# Altium schematic sheet Microcontrollers.SchDoc(Microcontrollers)
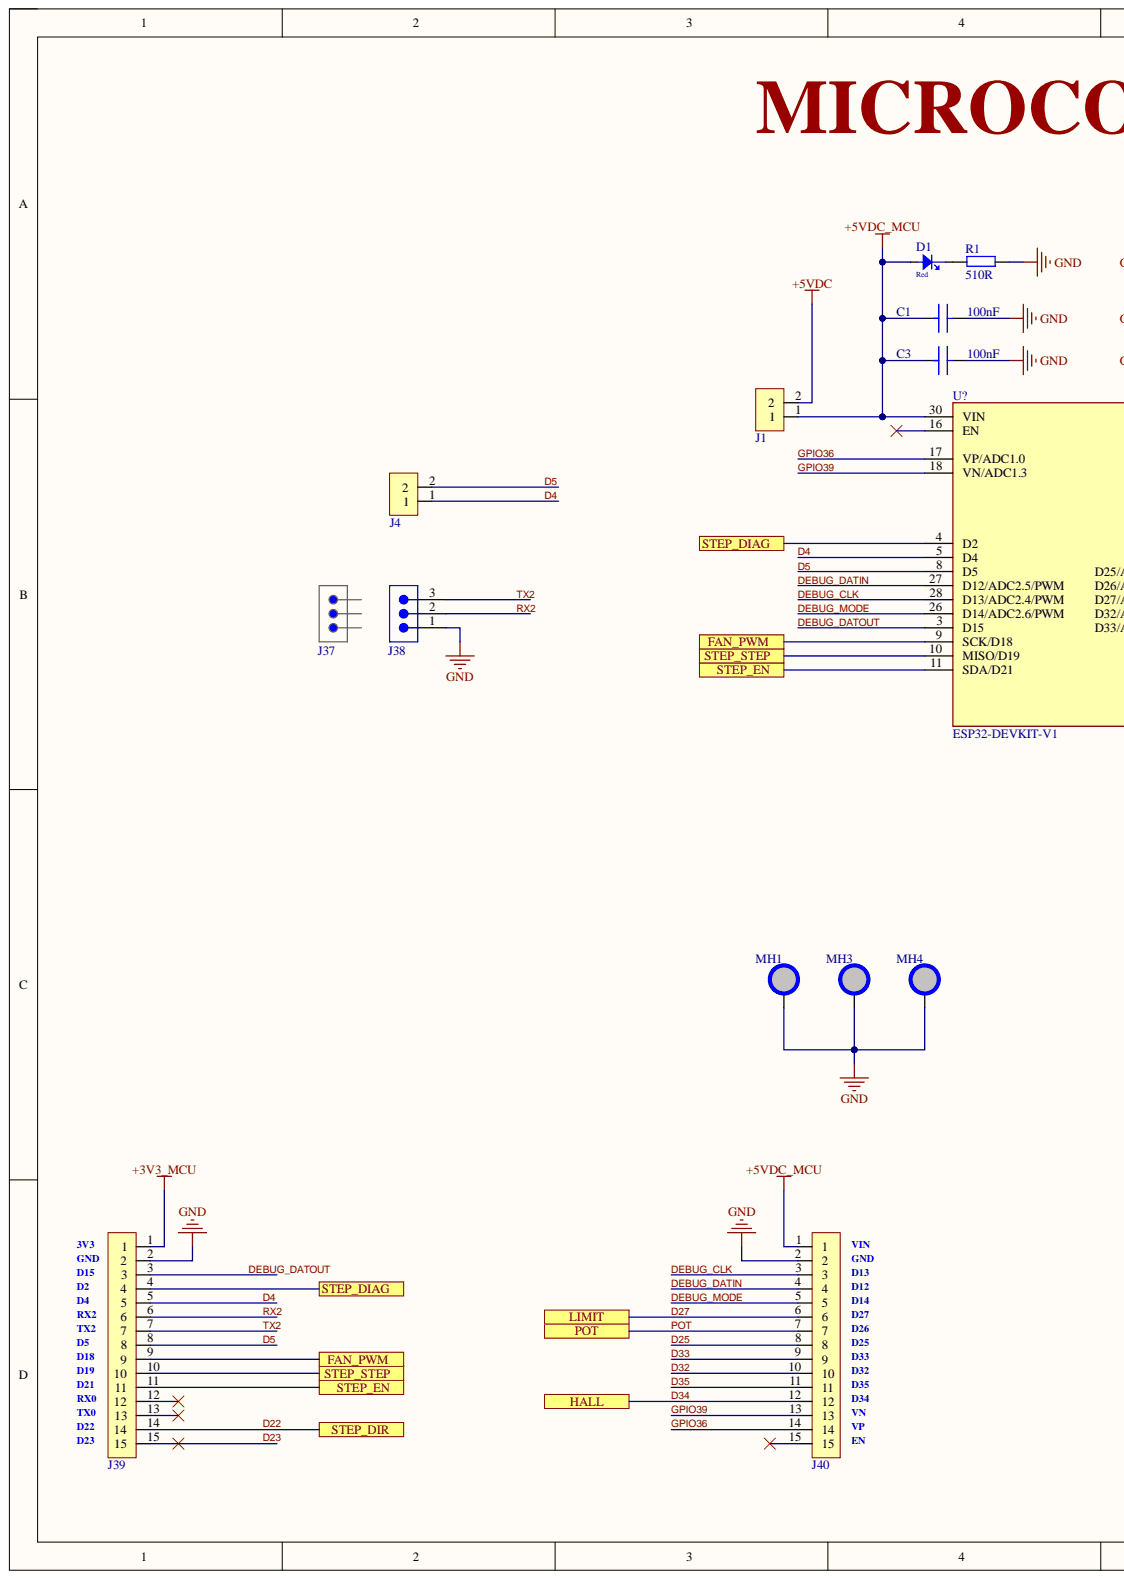
[diagram p0: Microcontrollers.SchDoc, left]
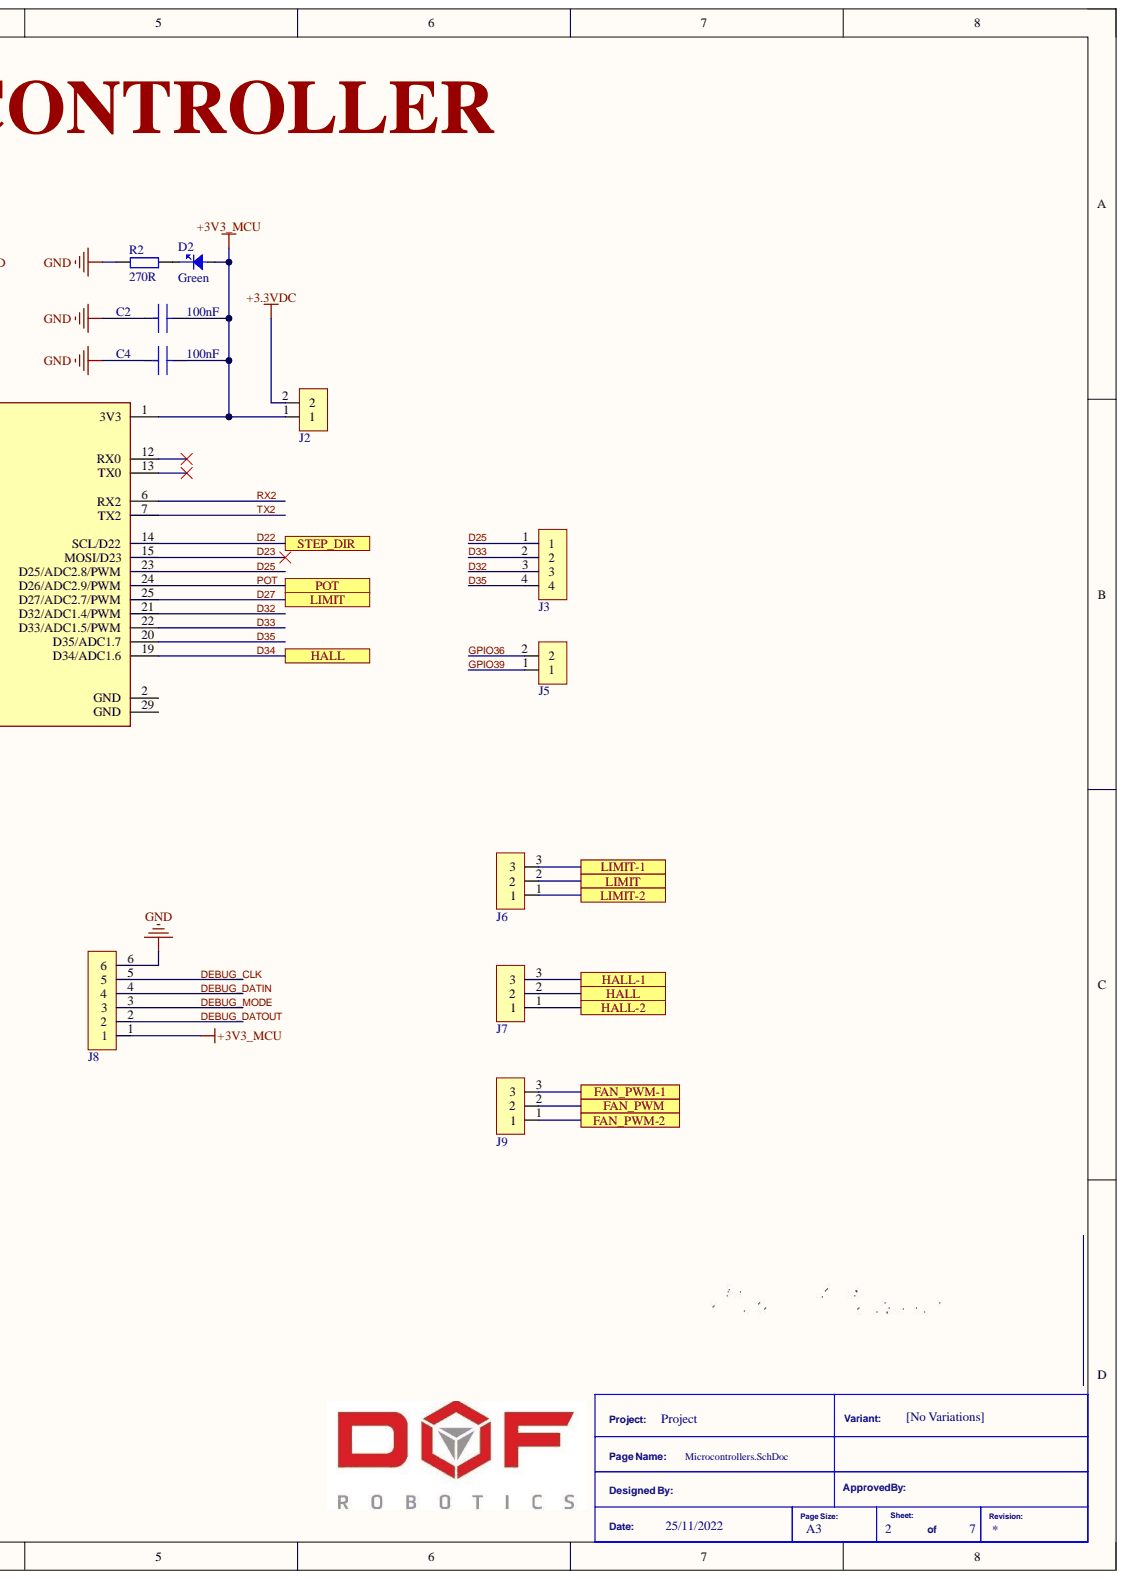
[diagram p1: Microcontrollers.SchDoc, right]

## Parts
C1  Capacitance: 100nF  [CAP CER 0805]  (hidden comment: CL21B104KACNNNC)
C2  Capacitance: 100nF  [CAP CER 0805]  (hidden comment: CL21B104KACNNNC)
C3  Capacitance: 100nF  [CAP CER 0805]  (hidden comment: CL21B104KACNNNC)
C4  Capacitance: 100nF  [CAP CER 0805]  (hidden comment: CL21B104KACNNNC)
D1  Color: Red  [LED RED 0603]  (hidden comment: 150060RS75000)
D2  Color: Green  [LED GREEN 0805]  (hidden comment: CMD17-21VGD/TR8)
J1  61300211121  [HDR_ML_1X2]  (value not drawn)
J2  61300211121  [HDR_ML_1X2]  (value not drawn)
J3  M20-9990345  [HDR_ML_1X4]  (value not drawn)
J4  61300211121  [HDR_ML_1X2]  (value not drawn)
J5  61300211121  [HDR_ML_1X2]  (value not drawn)
J6  61300311121  [HDR_ML_1X3]  (value not drawn)
J7  61300311121  [HDR_ML_1X3]  (value not drawn)
J8  61300611121  [HDR_ML_1x6]  (value not drawn)
J9  61300311121  [HDR_ML_1X3]  (value not drawn)
J37  691352710003  [CONN FML 1X3 WURTH 691352710003]  (value not drawn)
J38  691313710003  [CONN ML 1X3 WURTH 691313710003]  (value not drawn)
J39  M20-7821546  [HDR_FML_1X15]  (value not drawn)
J40  M20-7821546  [HDR_FML_1X15]  (value not drawn)
MH1  MH_5.5MMC_3.1MMD  [MH_5.5MMC_3.1MMD]  (value not drawn)  (no BOM)
MH3  MH_5.5MMC_3.1MMD  [MH_5.5MMC_3.1MMD]  (value not drawn)  (no BOM)
MH4  MH_5.5MMC_3.1MMD  [MH_5.5MMC_3.1MMD]  (value not drawn)  (no BOM)
R1  Resistance: 510R  [RES 0603]  (hidden comment: ESR03EZPJ511)
R2  Resistance: 270R  [RES 0805]  (hidden comment: CRGCQ0805F270R)

## Ports
FAN_PWM, FAN_PWM-1, FAN_PWM-2, HALL, HALL-1, HALL-2, LIMIT, LIMIT-1, LIMIT-2, POT, STEP_DIAG, STEP_DIR, STEP_EN, STEP_STEP

## Nets
+3.3VDC: J2.2
+3V3_MCU: C2.1 C4.1 D2.2 J2.1 J8.1 J39.1
+5VDC: J1.2
+5VDC_MCU: C1.2 C3.2 D1.2 J1.1 J40.1
GND: C1.1 C2.2 C3.1 C4.2 J8.6 J38.1 J39.2 J40.2 MH1.1 MH3.1 MH4.1 R1.2 R2.2
D4: J4.1 J39.5
D5: J4.2 J39.8
D22: J39.14 ; port STEP_DIR
D23: J39.15
D25: J3.1 J40.8
D27: J6.2 J40.6 ; port LIMIT
D32: J3.3 J40.10
D33: J3.2 J40.9
D34: J7.2 J40.12 ; port HALL
D35: J3.4 J40.11
DEBUG_CLK: J8.5 J40.3
DEBUG_DATIN: J8.4 J40.4
DEBUG_DATOUT: J8.2 J39.3
DEBUG_MODE: J8.3 J40.5
FAN_PWM: J9.2 J39.9 ; port FAN_PWM
FAN_PWM-1: J9.3 ; port FAN_PWM-1
FAN_PWM-2: J9.1 ; port FAN_PWM-2
GPIO36: J5.2 J40.14
GPIO39: J5.1 J40.13
HALL-1: J7.3 ; port HALL-1
HALL-2: J7.1 ; port HALL-2
LIMIT-1: J6.3 ; port LIMIT-1
LIMIT-2: J6.1 ; port LIMIT-2
POT: J40.7 ; port POT
RX2: J38.2 J39.6
STEP_DIAG: J39.4 ; port STEP_DIAG
STEP_EN: J39.11 ; port STEP_EN
STEP_STEP: J39.10 ; port STEP_STEP
TX2: J38.3 J39.7
NetD1_1: D1.1 R1.1
NetD2_1: D2.1 R2.1

Not connected: J39 12 13; J40 15

## Library data of the parts
C1-C4 = CAP CER 0.1UF 25V X7R 0805: Manufacturer: Samsung; Tolerance: 10%; Case Code (Imperial): 0805; Case Code (Metric): 2012; Case/Package: 0805; Category: Ceramic Capacitors; Component Kind: Standard; ComponentLink1Description: https://datasheet.ciiva.com/25801/cl21b104kacnnnc-25801824.pdf?src-supplier=Digi-Key; ComponentLink1URL: https://datasheet.ciiva.com/25801/cl21b104kacnnnc-25801824.pdf?src-supplier=Digi-Key; ComponentLink2Description: https://datasheet.ciiva.com/15216/getdatasheetpartid-355204-15216236.pdf?src-supplier=Verical; ComponentLink2URL: https://datasheet.ciiva.com/15216/getdatasheetpartid-355204-15216236.pdf?src-supplier=Verical; Construction: Flat; Contact Plating: Tin; Depth: 1.25mm; Description: CAP CER 0.1UF 25V X7R 0805; Dielectric: X7R; Height: 0.85mm; Length: 2mm; Manufacturer Part Number: CL21B104KACNNNC; Max Operating Temperature: 125°C; Min Operating Temperature: -55°C; Mount: Surface Mount; Package Quantity: 1; Packaging: Tape and Reel; Pricing 1: 1=0.10000, 10=0.04000, 50=0.02200, 100=0.01790, 500=0.01282, 1000=0.01007 (USD); Radiation Hardening: No; RoHS: Yes; RoHS Compliant: Yes; Series: CL; Stock 1: 2617; Supplier 1: Digi-Key; Supplier Part Number 1: 1276-1099-1-ND; Thickness: 0.037inch; Voltage: 25V; Voltage Rating: 25V; Width: 0.049inch; Library Name: LibEnes.SchLib
D1 = LED RED 0603: WURTH ELEKTRONIK - 150060RS75000 - LED, Red, 625 nm, 2 V, 30 mA, 250 mcd RoHS Compliant: Yes; Manufacturer: Wurth Electronics; Dominant Wavelength: 625nm; Forward Current: 30mA; Forward Voltage: 2V; Lens Style: Clear,Rectangular; Luminous Intensity: 250mcd; Test Current: 20mA; Viewing Angle: 140°; Case Code (Imperial): 0603; Case Code (Metric): 1608; Case/Package: 0603; Category: LEDs; Component Kind: Standard; ComponentLink1Description: https://datasheet.ciiva.com/29563/150060rs75000-29563040.pdf?src-supplier=Digi-Key; ComponentLink1URL: https://datasheet.ciiva.com/29563/150060rs75000-29563040.pdf?src-supplier=Digi-Key; ComponentLink2Description: https://datasheet.ciiva.com/29279/759428-da-01-en-chipled-0603-w-kl-rot-150060rs75000-29279196.pdf?src-supplier=Conrad; ComponentLink2URL: https://datasheet.ciiva.com/29279/759428-da-01-en-chipled-0603-w-kl-rot-150060rs75000-29279196.pdf?src-supplier=Conrad; Height: 0.7mm; Length: 1.6mm; Manufacturer Part Number: 150060RS75000; Max Operating Temperature: 85°C; Min Operating Temperature: -40°C; Mount: Surface Mount; Package Quantity: 1; Packaging: Cut Tape; Pricing 1: 1=0.14000, 50=0.13300, 100=0.12000, 500=0.11400, 1000=0.09900 (USD); REACH SVHC: Unknown; RoHS: Yes; RoHS Compliant: Yes; Stock 1: 253591; Supplier 1: Digi-Key; Supplier Part Number 1: 732-4978-1-ND; Wavelength: 625nm; Weight: 0.0025; Width: 0.8mm; Library Name: LibEnes.SchLib
D2 = LED GREEN 0805: LED, Green, SMD 0805, 7.0 mcd, Green Diffused Lens; Manufacturer: VCC CHML; Forward Current: 20mA; Forward Voltage: 2.1V; Lens Style: Rectangular,Diffused,Tinted,Colored; Luminous Intensity: 7mcd; Number of Elements: 1; Power Dissipation: 105mW; Reverse Voltage: 5V; Viewing Angle: 140°; Wavelength: 570nm; Case Code (Imperial): 0805; Case Code (Metric): 2012; Case/Package: 0805; Category: LEDs; Component Kind: Standard; ComponentLink1Description: https://datasheet.ciiva.com/46391/719069-46391062.pdf?src-supplier=Element14; ComponentLink1URL: https://datasheet.ciiva.com/46391/719069-46391062.pdf?src-supplier=Element14; ComponentLink2Description: https://datasheet.ciiva.com/16437/cmd17-21seriessmtleds0805packagesize-16437018.pdf?src-supplier=Future+Electronics; ComponentLink2URL: https://datasheet.ciiva.com/16437/cmd17-21seriessmtleds0805packagesize-16437018.pdf?src-supplier=Future+Electronics; Depth: 1.25mm; Height: 1.1mm; Illumination Color: Green; Lead Free: Lead Free; Length: 2mm; Library Name: My_SCH_Lib.SchLib; Manufacturer Part Number: CMD17-21VGD/TR8; Max Operating Temperature: 85°C; Min Operating Temperature: -40°C; Mount: Surface Mount; Number of LEDs: 1; Number of Pins: 2; Package Quantity: 3000; Packaging: Tape and Reel; Power Rating: 105mW; Pricing 1: 1=0.69000, 10=0.45100, 100=0.29850, 1000=0.23051 (USD); REACH SVHC: Unknown; Reverse Voltage (DC): 5V; RoHS: Yes; Stock 1: 77654; Supplier 1: Digi-Key; Supplier Part Number 1: L62505DKR-ND; Test Current: 20mA; Width: 1.25mm
J1 J2 J4 J5 = HDR_ML_1X2 Würth: CONN HEADER 2 POS 2.54; Manufacturer: Wurth Electronics; Connector Type: Male Pin; Current Rating: 3A; Gender: Male; Number of Contacts: 2; Pitch: 0.1inch; Voltage Rating: 250V; Category: Headers and Wire Housings; Color: Black; Component Kind: Standard; ComponentLink1Description: https://datasheet.ciiva.com/13619/6130xx11121-48870-13619345.pdf?src-supplier=Mouser; ComponentLink1URL: https://datasheet.ciiva.com/13619/6130xx11121-48870-13619345.pdf?src-supplier=Mouser; Contact Gender: Male; Contact Material: Copper Alloy,Copper; Contact Mating Length: 0.236inch; Contact Plating: Gold; Contact Type: Male Pin; Housing Material: PBT; Lead Free: Lead Free; Manufacturer Part Number: 61300211121; Max Operating Temperature: 125°C; Min Operating Temperature: -40°C; Mount: Through Hole; Number of Positions: 2; Number of Rows: 1; Packaging: Bulk; Pricing 1: 1=0.13000, 10=0.11300, 25=0.09400, 50=0.07900, 100=0.07100, 1000=0.06500 (USD); REACH SVHC: Unknown; RoHS: Yes; RoHS Compliant: Yes; Series: WR-PHD; Stock 1: 261126; Supplier 1: Digi-Key; Supplier Part Number 1: 732-5315-ND; Termination: Through Hole; Library Name: LibEnes.SchLib
J3 = HDR_ML_1X3: HARWIN - M20-9990345 - Board-To-Board Connector, Straight, 2.54 mm, 3 Contacts, Header, M20 Series, Through Hole, 1 Rows; Connector Type: Male Pin; Contact Resistance: 25mR; Current Rating: 3A; Insulation Resistance: 10GR; Number of Contacts: 3; Pitch: 2.54mm; Voltage Rating (AC): 750V; Case/Package: SIL; Category: Headers and Wire Housings; Color: Black; Component Kind: Standard; ComponentLink1Description: https://datasheet.ciiva.com/11747/0900766b80da602d-11747505.pdf?src-supplier=RSComponents; ComponentLink1URL: https://datasheet.ciiva.com/11747/0900766b80da602d-11747505.pdf?src-supplier=RSComponents; ComponentLink2Description: https://datasheet.ciiva.com/10151/m20-999-10151334.pdf?src-supplier=Avnet; ComponentLink2URL: https://datasheet.ciiva.com/10151/m20-999-10151334.pdf?src-supplier=Avnet; ComponentLink3Description: https://datasheet.ciiva.com/16657/m20-999-16657084.pdf?src-supplier=Avnet+Europe; ComponentLink3URL: https://datasheet.ciiva.com/16657/m20-999-16657084.pdf?src-supplier=Avnet+Europe; ComponentLink4Description: https://datasheet.ciiva.com/5160/m20-999-351179-5160492.pdf?src-supplier=Mouser; ComponentLink4URL: https://datasheet.ciiva.com/5160/m20-999-351179-5160492.pdf?src-supplier=Mouser; Contact Material: Brass,Bronze; Contact Mating Length: 0.24inch; Contact Plating: Gold; Contact Type: Male Pin; Depth: 2.54mm; Height: 0.125inch; Housing Color: Black; Housing Material: Nylon; Length: 7.62mm; Manufacturer: Harwin; Manufacturer Part Number: M20-9990345; Max Current Rating: 3A; Max Operating Temperature: 105°C; Min Operating Temperature: -40°C; Mount: Through Hole; Number of Positions: 3; Number of Rows: 1; Orientation: Straight; Package Quantity: 1000; Packaging: Bulk; Pricing 1: 1=0.19000, 10=0.17600, 100=0.10840, 500=0.08968, 1000=0.07848, 5000=0.06727, 10000=0.06540 (USD); Radiation Hardening: No; REACH SVHC: No SVHC; RoHS: Yes; RoHS Compliant: Yes; Series: M20; Stock 1: 50550; Supplier 1: Digi-Key; Supplier Part Number 1: 952-2263-ND; Termination: Solder; Weight: 0.18; Width: 0.25inch; Library Name: LibEnes.SchLib
J6 J7 J9 = HDR ML 1X3 WURTH 61300311121: CONN HEADER 3 POS 2.54; Manufacturer: Wurth Electronics; Connector Type: Male Pin; Gender: Male; Number of Contacts: 3; Pitch: 0.1inch; Category: Connectors; Color: Black; Component Kind: Standard; ComponentLink1Description: http://www.farnell.com/cad/1737268.pdf?src-supplier=Element14; ComponentLink1URL: http://www.farnell.com/cad/1737268.pdf?src-supplier=Element14; ComponentLink2Description: https://katalog.we-online.de/em/datasheet/6130xx11121.pdf?src-supplier=Digi-Key; ComponentLink2URL: https://katalog.we-online.de/em/datasheet/6130xx11121.pdf?src-supplier=Digi-Key; Contact Material: Copper; Contact Mating Length: 0.236inch; Contact Plating: Gold; Lead Free: Lead Free; Manufacturer Part Number: 61300311121; Mount: Through Hole; Number of Positions: 3; Number of Rows: 1; Packaging: Bulk; Pricing 1: 1=0.13000, 10=0.11300, 25=0.09400, 50=0.07900, 100=0.07100, 1000=0.06600 (USD); REACH SVHC: Unknown; RoHS: Yes; RoHS Compliant: Yes; Stock 1: 76429; Supplier 1: Digi-Key; Supplier Part Number 1: 732-5316-ND; Termination: Solder; Library Name: LibEnes.SchLib
J8 = HDR_ML_1X6 Würth: CONN HEADER 6 POS 2.54; Manufacturer: Wurth Electronics; Connector Type: Male Pin; Current Rating: 3A; Gender: Male; Number of Contacts: 6; Pitch: 0.1inch; Voltage Rating: 250V; Category: Headers and Wire Housings; Color: Black; Component Kind: Standard; ComponentLink1Description: http://www.mouser.com/ds/2/445/6130xx11121-538006.pdf?src-supplier=Mouser; ComponentLink1URL: http://www.mouser.com/ds/2/445/6130xx11121-538006.pdf?src-supplier=Mouser; ComponentLink2Description: http://www.farnell.com/cad/1737268.pdf?src-supplier=Element14; ComponentLink2URL: http://www.farnell.com/cad/1737268.pdf?src-supplier=Element14; ComponentLink3Description: https://katalog.we-online.de/em/datasheet/6130xx11121.pdf?src-supplier=Digi-Key; ComponentLink3URL: https://katalog.we-online.de/em/datasheet/6130xx11121.pdf?src-supplier=Digi-Key; Contact Gender: Male; Contact Material: Copper Alloy,Copper; Contact Mating Length: 0.236inch; Contact Plating: Gold; Contact Type: Male Pin; Housing Material: PBT; Lead Free: Lead Free; Manufacturer Part Number: 61300611121; Max Operating Temperature: 125°C; Min Operating Temperature: -40°C; Mount: Through Hole; Number of Positions: 6; Number of Rows: 1; Packaging: Bulk; Pricing 1: 1=0.35000, 10=0.29800, 25=0.24720, 50=0.20700, 100=0.18700, 1000=0.17100 (USD); REACH SVHC: Unknown; RoHS: Yes; RoHS Compliant: Yes; Series: WR-PHD; Stock 1: 68710; Supplier 1: Digi-Key; Supplier Part Number 1: 732-5319-ND; Termination: Through Hole; Library Name: LibEnes.SchLib
J37 = CONN FML 1X3 WURTH 691352710003: Pluggable Terminal Block, 3, 300 V, 12 A, 5 Mm, 24 Awg, 12 Awg Rohs Compliant: Yes  (Mate to 691313710003); Manufacturer: Wurth Electronics; Current: 20A; Current Rating: 12A; Number of Contacts: 3; Number of Levels: 1; Pitch: 0.197inch; Stripping Length: -7mm; Voltage: 300V; Wire Gauge (Max): 24AWG; Wire Gauge (Min): 12AWG; Category: Terminal Blocks; Color: Green; Component Kind: Standard; ComponentLink1Description: https://datasheet.ciiva.com/7992/1442233-7992380.pdf?src-supplier=Element14; ComponentLink1URL: https://datasheet.ciiva.com/7992/1442233-7992380.pdf?src-supplier=Element14; ComponentLink2Description: https://datasheet.ciiva.com/796/6913527100xx-796216.pdf?src-supplier=Digi-Key; ComponentLink2URL: https://datasheet.ciiva.com/796/6913527100xx-796216.pdf?src-supplier=Digi-Key; Contact Material: Brass; Length: 18.2mm; Manufacturer Part Number: 691352710003; Mount: Free Hanging; Number of Positions Per Level: 3; Package Quantity: 250; Packaging: Bulk; Pricing 1: 1=2.05000, 10=1.83900, 50=1.58800, 100=1.42100, 250=1.33700, 500=1.25400 (USD); REACH SVHC: Unknown; RoHS: Yes; RoHS Compliant: Yes; Series: WR-TBL Series 3527; Stock 1: 2478; Supplier 1: Digi-Key; Supplier Part Number 1: 732-2776-ND; Termination: Screw; Voltage Rating: 300V; Width: 15mm; Wire/Cable Gauge: 24AWG; Library Name: LibEnes.SchLib
J38 = CONN ML 1X3 WURTH 691313710003: 5mm Pitch Right Angle Pluggable Term Block; PCB Header; Through Hole; 3 Way  (Mate to 691352710003); Manufacturer: Wurth Electronics; Connector Type: PCB,Terminal Block; Current: 20A; Number of Contacts: 3; Number of Levels: 1; Pitch: 0.197inch; Voltage: 300V; Category: Terminal Blocks; Color: Green; Component Kind: Standard; ComponentLink1Description: https://datasheet.ciiva.com/8043/1442229-8043534.pdf?src-supplier=Element14; ComponentLink1URL: https://datasheet.ciiva.com/8043/1442229-8043534.pdf?src-supplier=Element14; ComponentLink2Description: https://datasheet.ciiva.com/795/6913137100xx-795838.pdf?src-supplier=Digi-Key; ComponentLink2URL: https://datasheet.ciiva.com/795/6913137100xx-795838.pdf?src-supplier=Digi-Key; ComponentLink3Description: https://datasheet.ciiva.com/48692/001088692-da-01-en-wr-tbl-terminal-block3137-691313710003-48692901.pdf?src-supplier=Conrad; ComponentLink3URL: https://datasheet.ciiva.com/48692/001088692-da-01-en-wr-tbl-terminal-block3137-691313710003-48692901.pdf?src-supplier=Conrad; Contact Material: Brass; Height: 12mm; Length: 12.5mm; Manufacturer Part Number: 691313710003; Mount: Through Hole; Number of Positions Per Level: 3; Number of Rows: 1; Package Quantity: 450; Packaging: Bulk; Pricing 1: 1=0.74000, 10=0.65700, 50=0.56800, 100=0.50800, 200=0.47800, 400=0.44800 (USD); REACH SVHC: Unknown; RoHS: Yes; RoHS Compliant: Yes; Series: WR-TBL Series 3137; Stock 1: 1376; Supplier 1: Digi-Key; Supplier Part Number 1: 732-2800-ND; Termination: Solder; Width: 17mm; Library Name: LibEnes.SchLib
J39 J40 = HDR_FML_1X15 Harwin M20-7821546: 2.54mm (0.1") Pitch SIL Vertical PC Tail Socket Assembly, tin, 15 contacts; Manufacturer: Harwin; Connector Type: Receptacle; Contact Resistance: 20mR; Gender: Female; Max Current Rating: 3A; Number of Positions: 15; Case/Package: SIL; Category: Headers and Wire Housings; Color: Black; Component Kind: Standard; ComponentLink1Description: https://datasheet.ciiva.com/5160/m20-782-351467-5160544.pdf?src-supplier=Mouser; ComponentLink1URL: https://datasheet.ciiva.com/5160/m20-782-351467-5160544.pdf?src-supplier=Mouser; Contact Gender: Female; Contact Material: Bronze; Contact Plating: Tin; Contact Type: Female Socket; Depth: 2.5mm; Housing Color: Black; Housing Material: Polyamide; Length: 38.5mm; Manufacturer Part Number: M20-7821546; Max Operating Temperature: 105°C; Min Operating Temperature: -40°C; Mount: Through Hole; Number of Rows: 1; Orientation: Straight; Package Quantity: 15; Packaging: Rail/Tube; Pitch: 2.54mm; Pricing 1: 1=1.85000, 10=1.61200, 100=1.44020, 500=1.20014, 1000=1.02868, 5000=0.91210, 10000=0.89153 (USD); RoHS: Yes; RoHS Compliant: Yes; Series: M20; Stock 1: 1864; Supplier 1: Digi-Key; Supplier Part Number 1: 952-2290-ND; Termination: Solder; Library Name: LibEnes.SchLib
MH1 MH3 MH4 = MH_5.5MMC_3.1MMD: Hole 5.5mm Diameter 3.1mm Drill NPTH; Library Name: LibEnes.SchLib
R1 = RES 510R 5% 0.25W 0603: Res Thick Film 0603 510 Ohm 5% 1/4W +/-200ppm/ßC Molded SMD SMD Paper T/R; Manufacturer: Rohm; Max Power Dissipation: 250mW; Temperature Coefficient: 200ppm/°C; Case Code (Imperial): 0603; Case Code (Metric): 1608; Case/Package: 0603; Category: Chip SMD Resistors; Component Kind: Standard; ComponentLink1Description: http://www.rohm.com/web/global/search/parametric/-/search/generateQRCode/dsheet/22/1/40101045/ESR10EZPD?src-supplier=Avnet; ComponentLink1URL: http://www.rohm.com/web/global/search/parametric/-/search/generateQRCode/dsheet/22/1/40101045/ESR10EZPD?src-supplier=Avnet; ComponentLink2Description: https://www.rohm.com/datasheet/ESR01MZPF/esr-e?src-supplier=Digi-Key; ComponentLink2URL: https://www.rohm.com/datasheet/ESR01MZPF/esr-e?src-supplier=Digi-Key; Contact Plating: Tin,Matte; Features: Automotive AEC-Q200; Lead Free: Lead Free; Manufacturer Part Number: ESR03EZPJ511; Max Operating Temperature: 155°C; Min Operating Temperature: -55°C; Number of Terminations: 2; Packaging: Tape and Reel; Pricing 1: 1=0.13000, 10=0.12100, 100=0.04640, 1000=0.02039, 2500=0.01761 (USD); Radiation Hardening: No; Resistor Type: Thick Film; RoHS: Yes; RoHS Compliant: Yes; Series: ESR; Stock 1: 144888; Supplier 1: Digi-Key; Supplier Part Number 1: RHM510DDKR-ND; Tolerance: 5%; Library Name: LibEnes.SchLib
R2 = RES 270R 1% 0.125W 0805: Res Thick Film 0805 270 Ohm 1% 0.125W(1/8W) ±100ppm/C Pad SMD Automotive T/R; Manufacturer: TE Connectivity; Category: Chip SMD Resistors; Component Kind: Standard; ComponentLink1Description: https://www.te.com/commerce/DocumentDelivery/DDEController?Action=srchrtrv&amp;DocNm=1773204-3&amp;DocType=DS&amp;DocLang=English&src-supplier=Digi-Key; ComponentLink1URL: https://www.te.com/commerce/DocumentDelivery/DDEController?Action=srchrtrv&amp;DocNm=1773204-3&amp;DocType=DS&amp;DocLang=English&src-supplier=Digi-Key; ComponentLink2Description: https://www.te.com/commerce/DocumentDelivery/DDEController?Action=srchrtrv&DocNm=1773204-3&DocType=DS&DocLang=English&src-supplier=Digi-Key; ComponentLink2URL: https://www.te.com/commerce/DocumentDelivery/DDEController?Action=srchrtrv&DocNm=1773204-3&DocType=DS&DocLang=English&src-supplier=Digi-Key; Manufacturer Part Number: CRGCQ0805F270R; Pricing 1: 1=0.10000, 10=0.04800, 100=0.01960, 1000=0.00879, 2500=0.00763 (USD); RoHS: Yes; Stock 1: 130113; Supplier 1: Digi-Key; Supplier Part Number 1: A129742CT-ND; Library Name: LibEnes.SchLib
